# Altium schematic sheet PL_IO.SchDoc
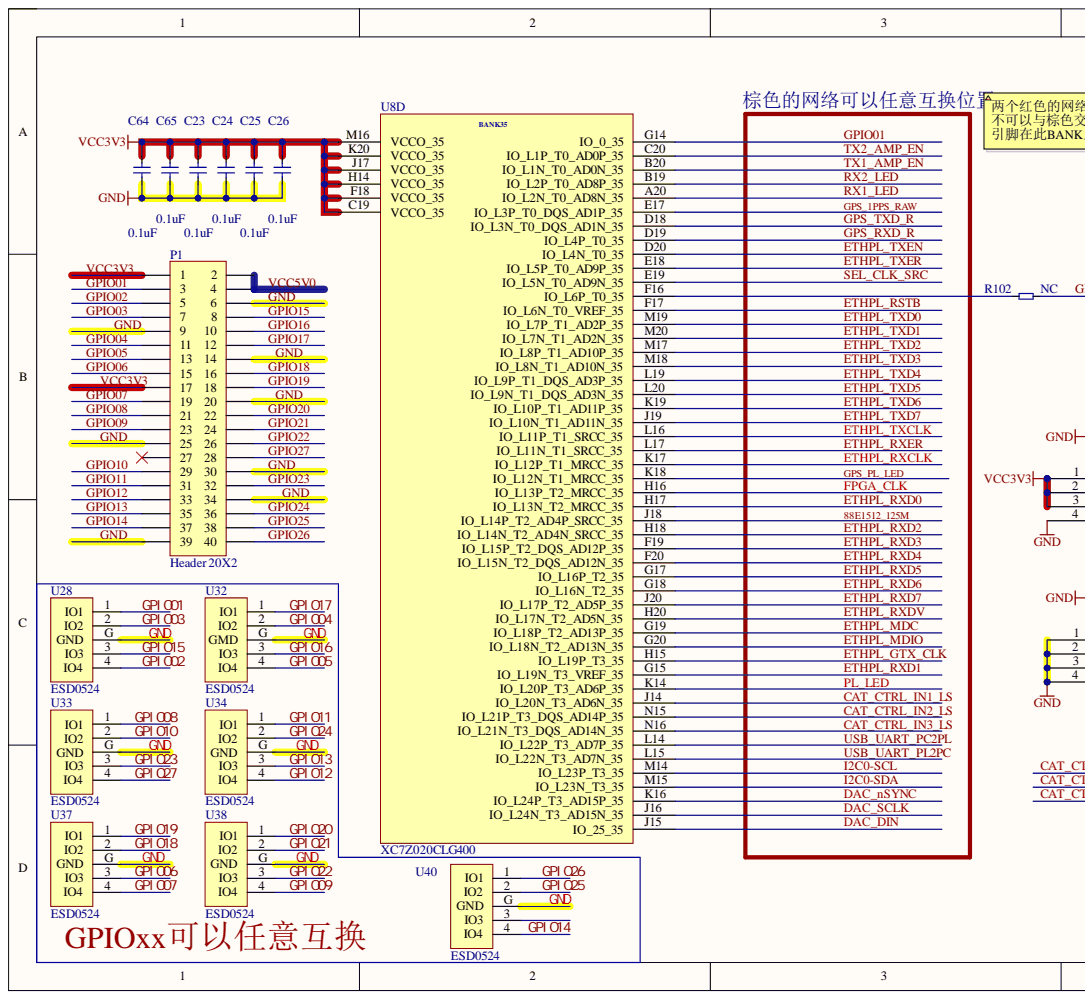
[diagram p0: PL_IO.SchDoc, left]
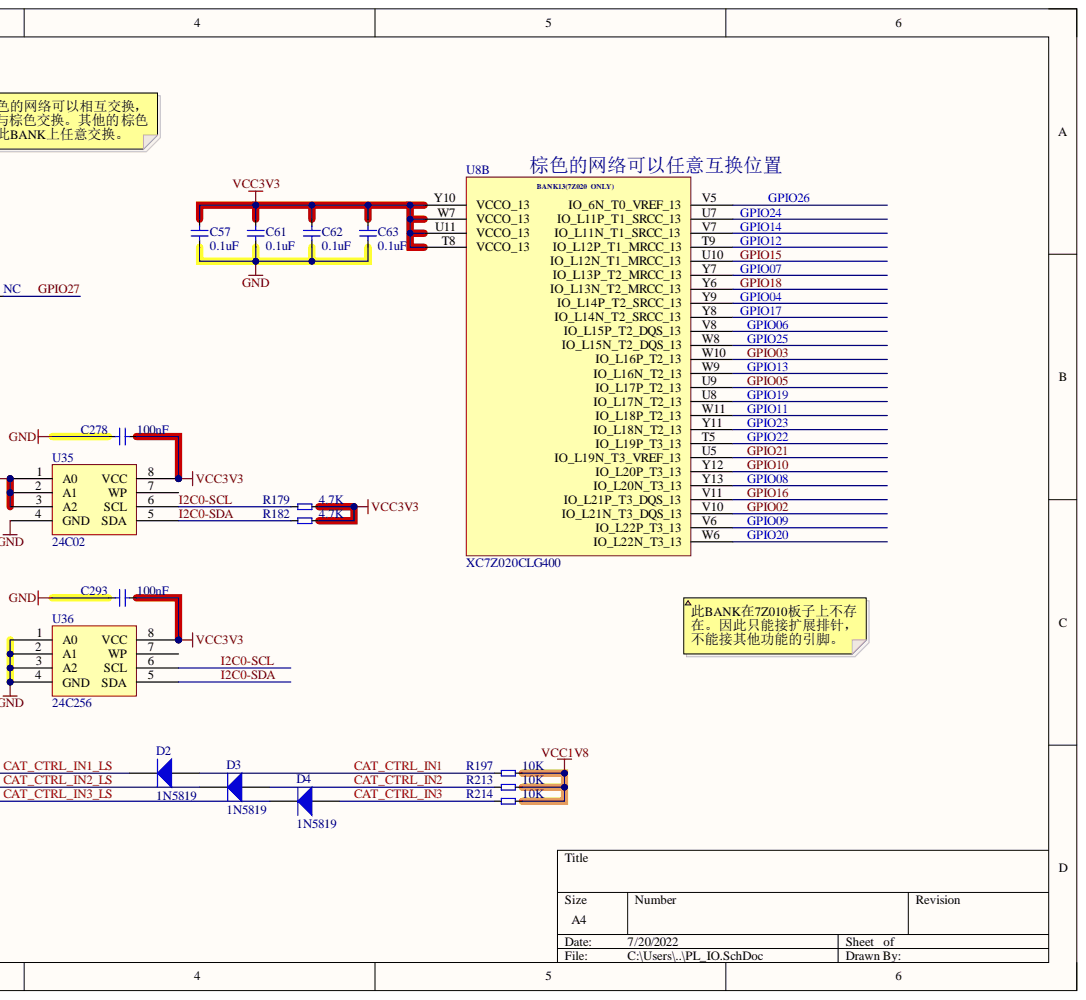
[diagram p1: PL_IO.SchDoc, right]

## Parts
C23  0.1uF  [C0201]
C24  0.1uF  [C0201]
C25  0.1uF  [C0201]
C26  0.1uF  [C0201]
C57  0.1uF  [C0201]
C61  0.1uF  [C0201]
C62  0.1uF  [C0201]
C63  0.1uF  [C0201]
C64  0.1uF  [C0201]
C65  0.1uF  [C0201]
C278  100nF  [C0201]
C293  100nF  [C0201]
D2  1N5819  [SOD-523_12]
D3  1N5819  [SOD-523_12]
D4  1N5819  [SOD-523_12]
P1  Header 20X2  [IDC-40J]
R102  NC  [R0201]
R179  4.7K  [R0201]
R182  4.7K  [R0201]
R197  10K  [R0201]
R213  10K  [R0201]
R214  10K  [R0201]
U8  XC7Z020CLG400  [MY_CLG400]  (parts B,D)
U28  ESD0524  [SESD0802Q4UG]
U32  ESD0524  [SESD0802Q4UG]
U33  ESD0524  [SESD0802Q4UG]
U34  ESD0524  [SESD0802Q4UG]
U35  24C02  [TSSOP8]
U36  24C256  [TSSOP8]
U37  ESD0524  [SESD0802Q4UG]
U38  ESD0524  [SESD0802Q4UG]
U40  ESD0524  [SESD0802Q4UG]

## Nets
VCC1V8: R197.2 R213.2 R214.2
88E1512_125M: U8.J18(IO_L14P_T2_AD4P_SRCC_35)
CAT_CTRL_IN1: D2.1 R197.1
CAT_CTRL_IN1_LS: D2.2 U8.J14(IO_L20N_T3_AD6N_35)
CAT_CTRL_IN2: D3.1 R213.1
CAT_CTRL_IN2_LS: D3.2 U8.N15(IO_L21P_T3_DQS_AD14P_35)
CAT_CTRL_IN3: D4.1 R214.1
CAT_CTRL_IN3_LS: D4.2 U8.N16(IO_L21N_T3_DQS_AD14N_35)
DAC_DIN: U8.J15(IO_25_35)
DAC_nSYNC: U8.K16(IO_L24P_T3_AD15P_35)
DAC_SCLK: U8.J16(IO_L24N_T3_AD15N_35)
ETHPL_GTX_CLK: U8.H15(IO_L19P_T3_35)
ETHPL_MDC: U8.G19(IO_L18P_T2_AD13P_35)
ETHPL_MDIO: U8.G20(IO_L18N_T2_AD13N_35)
ETHPL_RSTB: U8.F17(IO_L6N_T0_VREF_35)
ETHPL_RXCLK: U8.K17(IO_L12P_T1_MRCC_35)
ETHPL_RXD0: U8.H17(IO_L13N_T2_MRCC_35)
ETHPL_RXD1: U8.G15(IO_L19N_T3_VREF_35)
ETHPL_RXD2: U8.H18(IO_L14N_T2_AD4N_SRCC_35)
ETHPL_RXD3: U8.F19(IO_L15P_T2_DQS_AD12P_35)
ETHPL_RXD4: U8.F20(IO_L15N_T2_DQS_AD12N_35)
ETHPL_RXD5: U8.G17(IO_L16P_T2_35)
ETHPL_RXD6: U8.G18(IO_L16N_T2_35)
ETHPL_RXD7: U8.J20(IO_L17P_T2_AD5P_35)
ETHPL_RXDV: U8.H20(IO_L17N_T2_AD5N_35)
ETHPL_RXER: U8.L17(IO_L11N_T1_SRCC_35)
ETHPL_TXCLK: U8.L16(IO_L11P_T1_SRCC_35)
ETHPL_TXD0: U8.M19(IO_L7P_T1_AD2P_35)
ETHPL_TXD1: U8.M20(IO_L7N_T1_AD2N_35)
ETHPL_TXD2: U8.M17(IO_L8P_T1_AD10P_35)
ETHPL_TXD3: U8.M18(IO_L8N_T1_AD10N_35)
ETHPL_TXD4: U8.L19(IO_L9P_T1_DQS_AD3P_35)
ETHPL_TXD5: U8.L20(IO_L9N_T1_DQS_AD3N_35)
ETHPL_TXD6: U8.K19(IO_L10P_T1_AD11P_35)
ETHPL_TXD7: U8.J19(IO_L10N_T1_AD11N_35)
ETHPL_TXEN: U8.D20(IO_L4N_T0_35)
ETHPL_TXER: U8.E18(IO_L5P_T0_AD9P_35)
FPGA_CLK: U8.H16(IO_L13P_T2_MRCC_35)
GND: C23.2 C24.2 C25.2 C26.2 C57.2 C61.2 C62.2 C63.2 C64.2 C65.2 C278.2 C293.2 P1.6 P1.9 P1.14 P1.20 P1.25 P1.30 P1.34 P1.39 U28.G(GND) U32.G(GMD) U33.G(GND) U34.G(GND) U35.4(GND) U36.1(A0) U36.2(A1) U36.3(A2) U36.4(GND) U37.G(GND) U38.G(GND) U40.G(GND)
GPIO01: P1.3 U8.G14(IO_0_35) U28.1(IO1)
GPIO02: P1.5 U8.V10(IO_L21N_T3_DQS_13) U28.4(IO4)
GPIO03: P1.7 U8.W10(IO_L16P_T2_13) U28.2(IO2)
GPIO04: P1.11 U8.Y9(IO_L14P_T2_SRCC_13) U32.2(IO2)
GPIO05: P1.13 U8.U9(IO_L17P_T2_13) U32.4(IO4)
GPIO06: P1.15 U8.V8(IO_L15P_T2_DQS_13) U37.3(IO3)
GPIO07: P1.19 U8.Y7(IO_L13P_T2_MRCC_13) U37.4(IO4)
GPIO08: P1.21 U8.Y13(IO_L20N_T3_13) U33.1(IO1)
GPIO09: P1.23 U8.V6(IO_L22P_T3_13) U38.4(IO4)
GPIO10: P1.29 U8.Y12(IO_L20P_T3_13) U33.2(IO2)
GPIO11: P1.31 U8.W11(IO_L18P_T2_13) U34.1(IO1)
GPIO12: P1.33 U8.T9(IO_L12P_T1_MRCC_13) U34.4(IO4)
GPIO13: P1.35 U8.W9(IO_L16N_T2_13) U34.3(IO3)
GPIO14: P1.37 U8.V7(IO_L11N_T1_SRCC_13) U40.4(IO4)
GPIO15: P1.8 U8.U10(IO_L12N_T1_MRCC_13) U28.3(IO3)
GPIO16: P1.10 U8.V11(IO_L21P_T3_DQS_13) U32.3(IO3)
GPIO17: P1.12 U8.Y8(IO_L14N_T2_SRCC_13) U32.1(IO1)
GPIO18: P1.16 U8.Y6(IO_L13N_T2_MRCC_13) U37.2(IO2)
GPIO19: P1.18 U8.U8(IO_L17N_T2_13) U37.1(IO1)
GPIO20: P1.22 U8.W6(IO_L22N_T3_13) U38.1(IO1)
GPIO21: P1.24 U8.U5(IO_L19N_T3_VREF_13) U38.2(IO2)
GPIO22: P1.26 U8.T5(IO_L19P_T3_13) U38.3(IO3)
GPIO23: P1.32 U8.Y11(IO_L18N_T2_13) U33.3(IO3)
GPIO24: P1.36 U8.U7(IO_L11P_T1_SRCC_13) U34.2(IO2)
GPIO25: P1.38 U8.W8(IO_L15N_T2_DQS_13) U40.2(IO2)
GPIO26: P1.40 U8.V5(IO_6N_T0_VREF_13) U40.1(IO1)
GPIO27: P1.28 R102.2 U33.4(IO4)
GPS_1PPS_RAW: U8.E17(IO_L3P_T0_DQS_AD1P_35)
GPS_PL_LED: U8.K18(IO_L12N_T1_MRCC_35)
GPS_RXD_R: U8.D19(IO_L4P_T0_35)
GPS_TXD_R: U8.D18(IO_L3N_T0_DQS_AD1N_35)
I2C0-SCL: R179.1 U8.M14(IO_L23P_T3_35) U35.6(SCL) U36.6(SCL)
I2C0-SDA: R182.1 U8.M15(IO_L23N_T3_35) U35.5(SDA) U36.5(SDA)
PL_LED: U8.K14(IO_L20P_T3_AD6P_35)
RX1_LED: U8.A20(IO_L2N_T0_AD8N_35)
RX2_LED: U8.B19(IO_L2P_T0_AD8P_35)
SEL_CLK_SRC: U8.E19(IO_L5N_T0_AD9N_35)
TX1_AMP_EN: U8.B20(IO_L1N_T0_AD0N_35)
TX2_AMP_EN: U8.C20(IO_L1P_T0_AD0P_35)
USB_UART_PC2PL: U8.L14(IO_L22P_T3_AD7P_35)
USB_UART_PL2PC: U8.L15(IO_L22N_T3_AD7N_35)
VCC3V3: C23.1 C24.1 C25.1 C26.1 C57.1 C61.1 C62.1 C63.1 C64.1 C65.1 C278.1 C293.1 P1.1 P1.17 R179.2 R182.2 U8.C19(VCCO_35) U8.F18(VCCO_35) U8.H14(VCCO_35) U8.J17(VCCO_35) U8.K20(VCCO_35) U8.M16(VCCO_35) U8.T8(VCCO_13) U8.U11(VCCO_13) U8.W7(VCCO_13) U8.Y10(VCCO_13) U35.1(A0) U35.2(A1) U35.3(A2) U35.8(VCC) U36.8(VCC)
VCC5V0: P1.2 P1.4
NetR102_1: R102.1 U8.F16(IO_L6P_T0_35)

Not connected: P1 27; U35 7(WP); U36 7(WP); U40 3(IO3)

## Library data of the parts
C23-C26 C57 C61-C65 C278 C293 = C: Capacitor; Component Kind: Standard; Library Name: 电容-SCH.LIB
D2-D4 = SOD-123 B5819W  SL: Component Kind: Standard; ComponentLink1Description: 供应商链接; ComponentLink1URL: http://www.szlcsc.com/product/details_9093.html; Description: 1N5819; Library Name: Diode.SchLib; Package: SOD-123; Supplier: LC; SuppliersPartNumber: C8598
P1 = Header 20X2: Header, 20-Pin, Dual row; Component Kind: Standard; LatestRevisionDate: 17-Jul-2002; LatestRevisionNote: Re-released for DXP Platform.; Library Name: Miscellaneous Connectors.IntLib; Publisher: Altium Limited
R102 R179 R182 R197 R213 R214 = RES2: Component Kind: Standard; Library Name: 电阻-SCH.LIB
U35 U36 = AOZ1021_1: SO8 封装; Component Kind: Standard; Library Name: PCB_Project.SCHLIB
U8 = XC7Z020CLG400: Component Kind: Standard; Library Name: Schlib1.SchLib
U28 U32-U34 U37 U38 U40 = ESD0524: Component Kind: Standard; Library Name: ZED_PRJ.SCHLIB
